# Altium schematic sheet BCTester.SchDoc
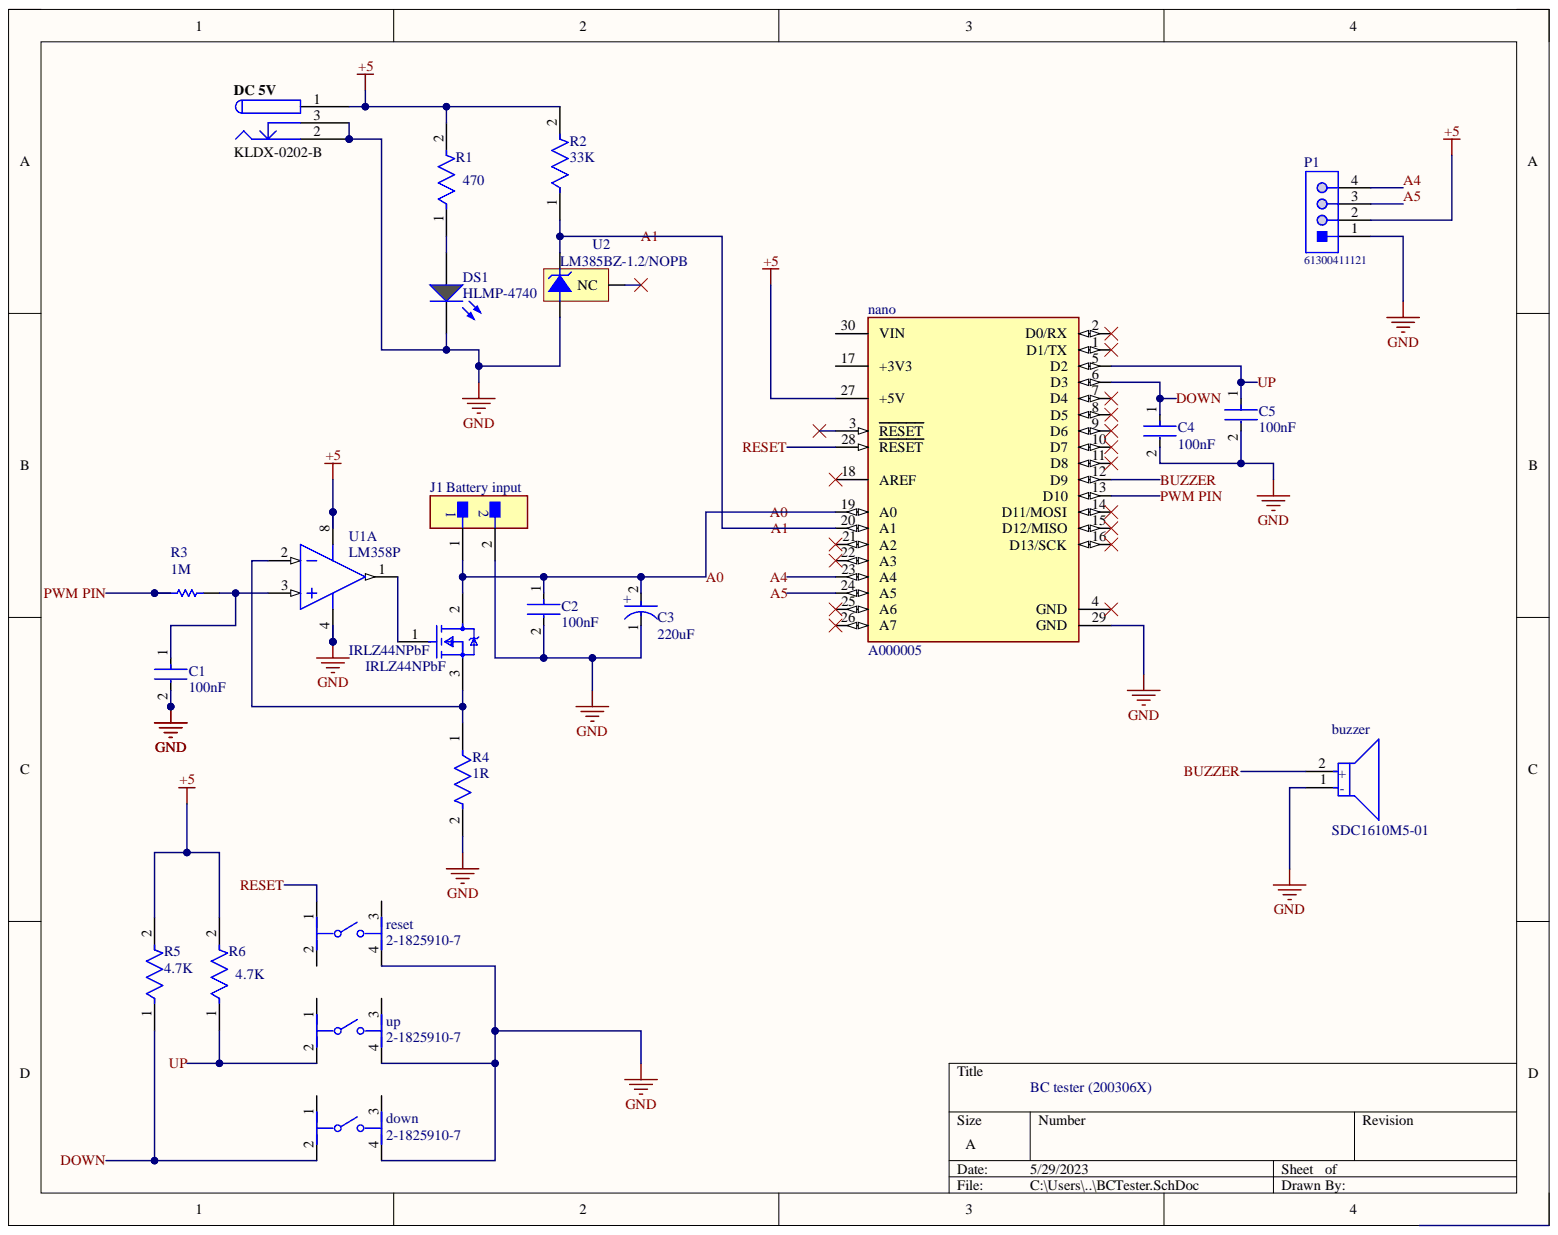
[diagram p0: BCTester.SchDoc]

## Parts
buzzer  SDC1610M5-01  [FP-SDC1610M5-01-MFG]
C1  100nF  [FP-DE6_D7_T7_F7_5_d0_6-0-MFG]
C2  100nF  [FP-DE6_D7_T7_F7_5_d0_6-0-MFG]
C3  220uF  [FP-RAD-TH-D_8_0_5-L_11_5-MFG]
C4  100nF  [FP-DE6_D7_T7_F7_5_d0_6-0-MFG]
C5  100nF  [FP-DE6_D7_T7_F7_5_d0_6-0-MFG]
DC_5V  KLDX-0202-B  [KYCN-KLDX-0202-B_V]
down  2-1825910-7  [FP-2-1825910-7-MFG]
DS1  HLMP-4740  [AVAG-HLMP-4740]
IRLZ44NPbF  IRLZ44NPbF  [FP-PG-TO220-3-MFG]
J1  Battery input  [FP-M20-9990246-MFG]
nano  A000005  [FP-A000005-MFG]
P1  61300411121  [61300411121]
R1  470  [FP-RNC55-MFG]
R2  33K  [FP-H8511KBYA-MFG]
R3  1M  [RESA60-630X240]
R4  1R  [FP-PR01-MFG]
R5  4.7K  [FP-MRS25-MFG]
R6  4.7K  [FP-MRS25-MFG]
reset  2-1825910-7  [FP-2-1825910-7-MFG]
U1  LM358P  [P0008A]  (part A)
U2  LM385BZ-1.2/NOPB  [Z03A]
up  2-1825910-7  [FP-2-1825910-7-MFG]

## Nets
+5: DC_5V.1 nano.27(+5V) P1.2 R1.2 R2.2 R5.2 R6.2 U1.8
GND: buzzer.1 C1.2 C2.2 C3.1 C4.2 C5.2 DC_5V.2 DC_5V.3 down.4 DS1.2 J1.2 nano.29(GND) P1.1 R4.2 reset.4 U1.4 U2.3 up.4
A0: C2.1 C3.2 IRLZ44NPbF.2 J1.1 nano.19(A0)
A1: nano.20(A1) R2.1 U2.2
A4: nano.23(A4) P1.4
A5: nano.24(A5) P1.3
BUZZER: buzzer.2 nano.12(D9)
DOWN: C4.1 down.2 nano.6(D3) R5.1
PWM PIN: nano.13(D10) R3.1
RESET: nano.28(RESET) reset.1
UP: C5.1 nano.5(D2) R6.1 up.2
NetC1_1: C1.1 R3.2 U1.3
NetDS1_1: DS1.1 R1.1
NetIRLZ44NPbF_1: IRLZ44NPbF.1 U1.1
NetIRLZ44NPbF_3: IRLZ44NPbF.3 R4.1 U1.2

Not connected: down 1 3; nano 1(D1/TX) 2(D0/RX) 3(RESET) 4(GND) 7(D4) 8(D5) 9(D6) 10(D7) 11(D8) 14(D11/MOSI) 15(D12/MISO) 16(D13/SCK) 17(+3V3) 18(AREF) 21(A2) 22(A3) 25(A6) 26(A7) 30(VIN); reset 2 3; U2 1; up 1 3

## Library data of the parts
buzzer = CMP-08413-000008-1: AUDIO MAGNETIC INDICATOR 4-8V TH; Frequency: 2.4kHz; Input Type: DC; Max Supply Current: 30mA; Nominal Supply Voltage (DC): 5V; Number of Terminals/Pins: 2; Component Kind: Standard; Diameter: 16mm; Height: 10mm; Lead Free: Lead Free; Library Name: Altium Content Vault; Max Operating Temperature: 70°C; Min Operating Temperature: -10°C; Mount: Through Hole; Number of Pins: 2; Operating Supply Current: 30mA; Packaging: Tray; Sensing Method: Magnetic; Series: SDC; Termination: PC Pins; Voltage Rating: 5V
C1 C2 C4 C5 = CMP-06035-062244-1: CAP CER 1000PF 300VAC RADIAL; Capacitance: 1nF; Lead Diameter: 0.6mm; Lead/Base Style: Formed Leads; Material: Ceramic; Tolerance: 20%; Voltage Rating (AC): 300V; Case/Package: Radial; Component Kind: Standard; Depth: 7mm; Height - Seated (Max): 0.394inch; Lead Pitch: 7.5mm; Library Name: Altium Content Vault; Max Operating Temperature: 125°C; Min Operating Temperature: -25°C; Mount: Through Hole; Package Quantity: 700; Packaging: Bulk; Ratings: AEC-Q200; REACH SVHC: No SVHC; Resistor Type: Ceramic; RoHS Compliant: Yes; Series: KJ; Termination: Radial; Width: 7mm
C3 = CMP-05427-001200-1: Aluminum Electrolytic Capacitors (Radial Lead Type) AEC-Q200 Qualified 220uF ±20% 25V; Lead Diameter: 0.6mm; Lead/Base Style: Straight; Life (Hours): 1000Hour; Polarity: Polar; Ripple Current: 230mA; Capacitance: 220uF; Case/Package: Radial; Component Kind: Standard; Construction: RADIAL; Contact Plating: Tin; Diameter: 8mm; Height: 11.5mm; Height - Seated (Max): 0.512inch; Lead Pitch: 3.5mm; Length: 11.5mm; Library Name: Altium Content Vault; Max Operating Temperature: 105°C; Min Operating Temperature: -55°C; Mount: Through Hole; Number of Pins: 2; Package Quantity: 200; Packaging: Bulk; REACH SVHC: No SVHC; Ripple Current (AC): 230mA; RoHS Compliant: Yes; Series: HD; Termination: Radial; Tolerance: 20%; Voltage: 25V; Voltage Rating: 25V; Voltage Rating (DC): 25V
DC_5V = CMP-0256-00001-1: 3 Conductor Power Jack, 2 break 2 aux contacts; Component Kind: Standard; ComponentLink1Description: Manufacturer URL; ComponentLink1URL: http://kycon.com/; ComponentLink2Description: Datasheet; ComponentLink2URL: http://anacapa.kycon.com/Catalog_PDF/KLDX_0202.pdf; Contact Finish: Brass; Library Name: Altium Content Vault; Mounting Technology: Through Hole; PackageDescription: Jack, 9 x 14.5 mm Body, 2.5 mm Center Pin Diameter; PackageReference: KLDX-0202-B; PartNumber: KLDX-0202-B
down reset up = CMP-03402-000004-1: SWITCH TACTILE SPST-NO 0.05A 24V; Manufacturer: TE Connectivity; Contact Current Rating: 50mA; Contact Resistance: 100mΩ; Electromechanical Life: 100000Cycles; Operating Force: 160gf; Automotive: No; Category: Switch; Component Kind: Standard; ComponentLink2Description: Datasheet; ComponentLink2URL: https://www.te.com/commerce/DocumentDelivery/DDEController?Action=showdoc&DocId=Customer+Drawing%7F1825910%7FC10%7Fpdf%7FEnglish%7FENG_CD_1825910_C10.pdf%7F2-1825910-7; Device Class L1: Electromechanical; Device Class L2: Switches; Device Class L3: Pushbutton Switches; Digikey Description: SWITCH TACTILE SPST-NO 0.05A 24V; Height: 13.3mm; Lead Free: Yes; Library Name: Altium Content Vault; Manufacturer Part Number: 2-1825910-7; Mount: Through Hole; Mouser Description: Switch Tactile OFF (ON) SPST Extended Round Button PC Pins 0.05A 24VDC 100000Cycles 1.57N Thru-Hole Loose; Octopart: https://octopart.com/2-1825910-7-te+connectivity-42270510; Package: 2-1825910-7; RoHS: TRUE; Temperature: -35°C to +85°C; Temperature Range High: +85°C; Temperature Range Low: -35°C; Throw Configuration: SPST-NO; Voltage Rating Dc: 24V
DS1 = CMP-2000-06413-1: T-1 3/4 (5 mm) Low Current LED Lamp, Green, 1.9 V, -20 to 100 degC, 2-Pin THD, RoHS, Bulk; Manufacturer: AvagoTechnologies; Dominant Wavelength/Color Temperature: 569nm; Intensity: 2.3mcd; Lens Appearance: ColoredDiffused; Lens Shape Type: Circular; Mounting Orientation: TopMount; Number of LEDs: 1; Peak Wavelength: 565nm; Rad Hard: No; Type: Uni-Color; Viewing Angle: 50°; Category: LEDs; Color: Green; Component Kind: Standard; ComponentLink1Description: New link; Datasheet URL: http://docs.avagotech.com/docs/AV02-1557EN; Datasheet Version: 07/2014; Library Name: Altium Content Vault; Manufacturer URL: http://www.avagotech.com/; Mounting: ThroughHole; Mounting Technology: ThroughHole; Package: 2T-13/4; Package Description: 2-Pin Through Hole Device, Dia 5.845 mm, Pitch 2.54 mm; Package Reference: HLMP-4740; Packaging: Bulk; Pin Count: 2
IRLZ44NPbF = CMP-32901-000488-1: MOSFET N-CH 55V 47A TO220AB; Drain to Source Resistance: 35mR; Element Configuration: Single; Gate to Source Voltage (Vgs): 16V; Input Capacitance: 1.7nF; Max Power Dissipation: 110W; Rds On Max: 22mR; Threshold Voltage: 2V; Turn-Off Delay Time: 26ns; Turn-On Delay Time: 11ns; Case/Package: TO-220; Component Kind: Standard; Continuous Drain Current (ID): 47A; Drain to Source Breakdown Voltage: 55V; Drain to Source Voltage (Vdss): 55V; Halogen Free: Halogen Free; Height: 0.6inch; Lead Free: Lead Free; Length: 0.414inch; Library Name: Altium Content Vault; Max Dual Supply Voltage: 55V; Max Operating Temperature: 175°C; Min Operating Temperature: -55°C; Mount: Through Hole; Number of Pins: 3; Packaging: Rail/Tube; Power Dissipation: 110W; REACH SVHC: No SVHC; RoHS Compliant: Yes; Width: 0.185inch
J1 = CMP-15831-000009-1: CONN HEADER VERT 2POS 2.54MM; Manufacturer: Harwin; Manufacturer Part Number: M20-9990246; Conn Gender: Male; Conn Orientation: Vertical; Current Rating: 3A; Number Of Contacts: 2; Automotive: No; Category: Conn; Component Kind: Standard; ComponentLink2Description: Datasheet; ComponentLink2URL: https://cdn.harwin.com/pdfs/M20-999.pdf; Contact Material: Tin,Nickel; Device Class L1: Connectors; Device Class L2: Headers and Wire Housings; Device Class L3: unset; Digikey Description: CONN HEADER VERT 2POS 2.54MM; Height: 8.99mm; Lead Free: Yes; Library Name: Altium Content Vault; Mouser Description: 2.54mm (0.1") Pitch SIL Vertical PC Tail Pin Header, 6.1mm mating pin height, tin, 2 contacts; Number Of Rows: 1; Octopart: https://octopart.com/m20-9990246-harwin-2590635; Package: M20-9990246; Pitch: 2.54mm; RoHS: TRUE; Temperature: -40°C to +105°C; Temperature Range High: +105°C; Temperature Range Low: -40°C
nano = CMP-189986-000002-1: ARDUINO NANO ATMEGA328 EVAL BRD; Core Architecture: AVR; Data Bus Width: 8b; Evaluation Kit: Yes; Interface: I2C; Number of Bits: 8; Operating Supply Voltage: 12V; Component Kind: Standard; Height: 0.25inch; Length: 7inch; Library Name: Altium Content Vault; Package Quantity: 50; Packaging: Bulk; REACH SVHC: No SVHC; Series: AVR® ATmega; Weight: 0.358383oz; Width: 5inch
P1 = CMP-1502-01064-1: THT Vertical Pin Header WR-PHD, Pitch 2.54 mm, Single Row, 4 pins; Manufacturer: Wurth Elektronik; Contact Resistance: 20mOhm; Gender: Male; Pin Number: 4; Pitch: 2.54mm; Rated Current: 3A; Withstanding Voltage: 500VAC; Working Voltage: 250VAC; Component Kind: Standard; Datasheet URL: https://katalog.we-online.de/em/datasheet/6130xx11121.pdf; Library Name: Altium Content Vault; Manufacturer URL: http://www.we-online.de; Mounting Technology: THT; Operating Temperature: -40to105degC; Orientation: Vertical; Package Reference: 61300411121; Packaging: Bag; Part Number: 61300411121; Row Number: Single; Publisher: WurthElektronik
R1 = CMP-02408-000004-1: RES 10K OHM 1/8W 1% AXIAL; Manufacturer: Vishay; Manufacturer Part Number: RNC55H1002FSB14; Power Rating: 0.125W; Resistance: 10kΩ; Temperature Coefficient: 50ppm/°C; Value: 10kΩ; Voltage Rating: 200V; Automotive: No; Category: Res; Component Kind: Standard; ComponentLink2Description: Datasheet; ComponentLink2URL: https://www.vishay.com/docs/31025/erc.pdf; Composition: Metal Film; Device Class L1: Passive Components; Device Class L2: Resistors; Device Class L3: Through-Hole Resistors; Digikey Description: RES 10K OHM 1/8W 1% AXIAL; Failure Rate: 0.001%; Height: 2.69mm; Ipc Land Pattern Name: RESAD1100W85L762D269; Lead Free: No; Library Name: Altium Content Vault; Military Standard: true; Octopart: https://octopart.com/rnc55h1002fs-vishay-40848808; Package: RNC55; RoHS: FALSE; Series: RNC; Temperature: -65°C to +175°C; Temperature Range High: +175°C; Temperature Range Low: -65°C; Tolerance: 1%
R2 = CMP-03212-000010-1: RES 511K OHM 0.1% 1/4W AXIAL; Lead Diameter: 0.6mm; Lead Length: 30mm; Max Power Dissipation: 250mW; Number of Resistors: 1; Resistance: 511kR; Temperature Coefficient: 15ppm/°C; Voltage Rating: 350V; Case/Package: Axial; Component Kind: Standard; Diameter: 2.5mm; ELV: Compliant; Lead/Base Style: Axial; Length: 7.2mm; Library Name: Altium Content Vault; Max Operating Temperature: 155°C; Min Operating Temperature: -55°C; Mount: Axial; Number of Terminations: 2; Package Quantity: 250; Packaging: Bulk; Power Rating: 250mW; REACH SVHC: No SVHC; Resistance Tolerance: 0.1%; Resistor Type: Thin Film; RoHS Compliant: Yes; Series: Holco, Holsworthy; Technology: METAL FILM; Termination: Axial; Tolerance: 0.1%; Voltage Rating (DC): 350V; Weight: 0.008466oz
R3 = CMP-1659-00070-1: Axial Resistor, 1 MOhm, +/- 1%, 250 mW, -55 to 155 degC, 2-Pin THD, RoHS, Bulk; Power Rating: 250 mW; Resistance: 47.5 kohm; Voltage Rating: 250 V; Case\Package: Axial; Component Kind: Standard; ComponentLink1Description: Manufacturer URL; ComponentLink1URL: http://www.yageo.com/; ComponentLink2Description: Datasheet; ComponentLink2URL: http://www.yageo.com/documents/recent/Yageo%20LR_MFR_2013.pdf; DatasheetVersion: Apr-13; Length: 0.248 inch; Library Name: Altium Content Vault; Max Operating Temperature: 155 degC; Min Operating Temperature: -55 degC; Mount: Through Hole; Package Quantity: 200; PackageDescription: 2-Pin Axial Lead, Dia 2.4 mm, Body Length 6.3 mm; PackageReference: MFR-25; Packaging: Bulk; RoHSCompliant: true; Tolerance: 1%
R4 = CMP-02408-000029-1: RES 75K OHM 1W 5% AXIAL; Lead Diameter: 0.58mm; Operating Supply Voltage: 350V; Resistance: 75kR; Temperature Coefficient: 250ppm/°C; Case/Package: Axial; Component Kind: Standard; Diameter: 2.5mm; Features: Flame Retardant Coating; Lead Free: Lead Free; Length: 0.256inch; Library Name: Altium Content Vault; Max Operating Temperature: 155°C; Max Power Dissipation: 1W; Min Operating Temperature: -55°C; Number of Pins: 2; Number of Terminations: 2; Package Quantity: 5000; Packaging: Tape and Reel; Power Rating: 1W; Resistance Tolerance: 5%; Resistor Type: Metal Film; RoHS Compliant: Yes; Series: PR01; Technology: METAL FILM; Termination: Axial; Tolerance: 5%; Voltage Rating: 350V; Voltage Rating (DC): 350V; Width: 2.5mm
R5 R6 = CMP-02408-000051-1: RES 1K OHM 0.6W 1% AXIAL; Lead Diameter: 0.6mm; Max Power Dissipation: 600mW; Resistance: 1kR; Temperature Coefficient: 50ppm/°C; Voltage Rating: 350V; Case/Package: Axial; Component Kind: Standard; Construction: Cylindrical; Contact Plating: Tin; Diameter: 2.5mm; Lead Free: Lead Free; Length: 6.5mm; Library Name: Altium Content Vault; Max Operating Temperature: 155°C; Military Standard: Not; Min Operating Temperature: -55°C; Mount: Through Hole; Number of Pins: 2; Number of Terminations: 2; Package Quantity: 5000; Packaging: Tape and Reel; Power Rating: 600mW; Radiation Hardening: No; Resistance Tolerance: 1%; Resistor Type: Metal Film; RoHS Compliant: Yes; Series: MRS25; Technology: THIN FILM; Termination: Axial; Tolerance: 1%; Voltage Rating (DC): 350V; Weight: 0.00776oz; Width: 2.5mm
U1 = CMP-2000-06549-1: Dual Operational Amplifier, 3 to 32 V, 0 to 70 degC, 8-Pin PDIP (P), RoHS, Tape and Reel; Manufacturer: Technik Industrial; Component Kind: Standard; Datasheet URL: http://www.ti.com/lit/ds/symlink/lm358.pdf; Datasheet Version: Rev. T, 04/2015; Library Name: Altium Content Vault; Manufacturer URL: http://www.technik.com.hk/; Mounting Technology: ThroughHole; Package Description: 8-Pin Double In-line Package, Body 9.59 x 6.35 mm, Pitch 2.54 mm; Package Reference: P8; Package URL: http://www.ti.com/lit/ml/mpdi001b/mpdi001b.pdf
U2 = CMP-0074-00028-2: Micropower Voltage Reference Diode, 3-pin TO-92, Pb-Free; Manufacturer: National Semiconductor; CompType: Voltage Reference IC; PackageTopMarking: NSXYTT*LM385*BZ1.2; PowerWise: N; Code_JEDEC: TO-226-AA; Component Kind: Standard; ComponentLink1Description: LM385-1.2 Datasheet; ComponentLink1URL: http://www.national.com/ds/LM/LM385-1.2.pdf; ComponentLink2Description: LM385-1.2 Web Page; ComponentLink2URL: http://www.national.com/pf/LM/LM385-1.2.html; ComponentLink3Description: Z03A Package Page; ComponentLink3URL: http://www.national.com/packaging/folders/z03a.html; DatasheetVersion: Jan-2008; Library Name: Altium Content Vault; PackageDescription: TO-92, Molded, 3 Lead; PackageReference: Z03A; PackageVersion: revG, Sep-2000; PinCount: 3; RevisionNote: Component URLs added; RoHS: Y
